# Altium schematic sheet sch3.SchDoc
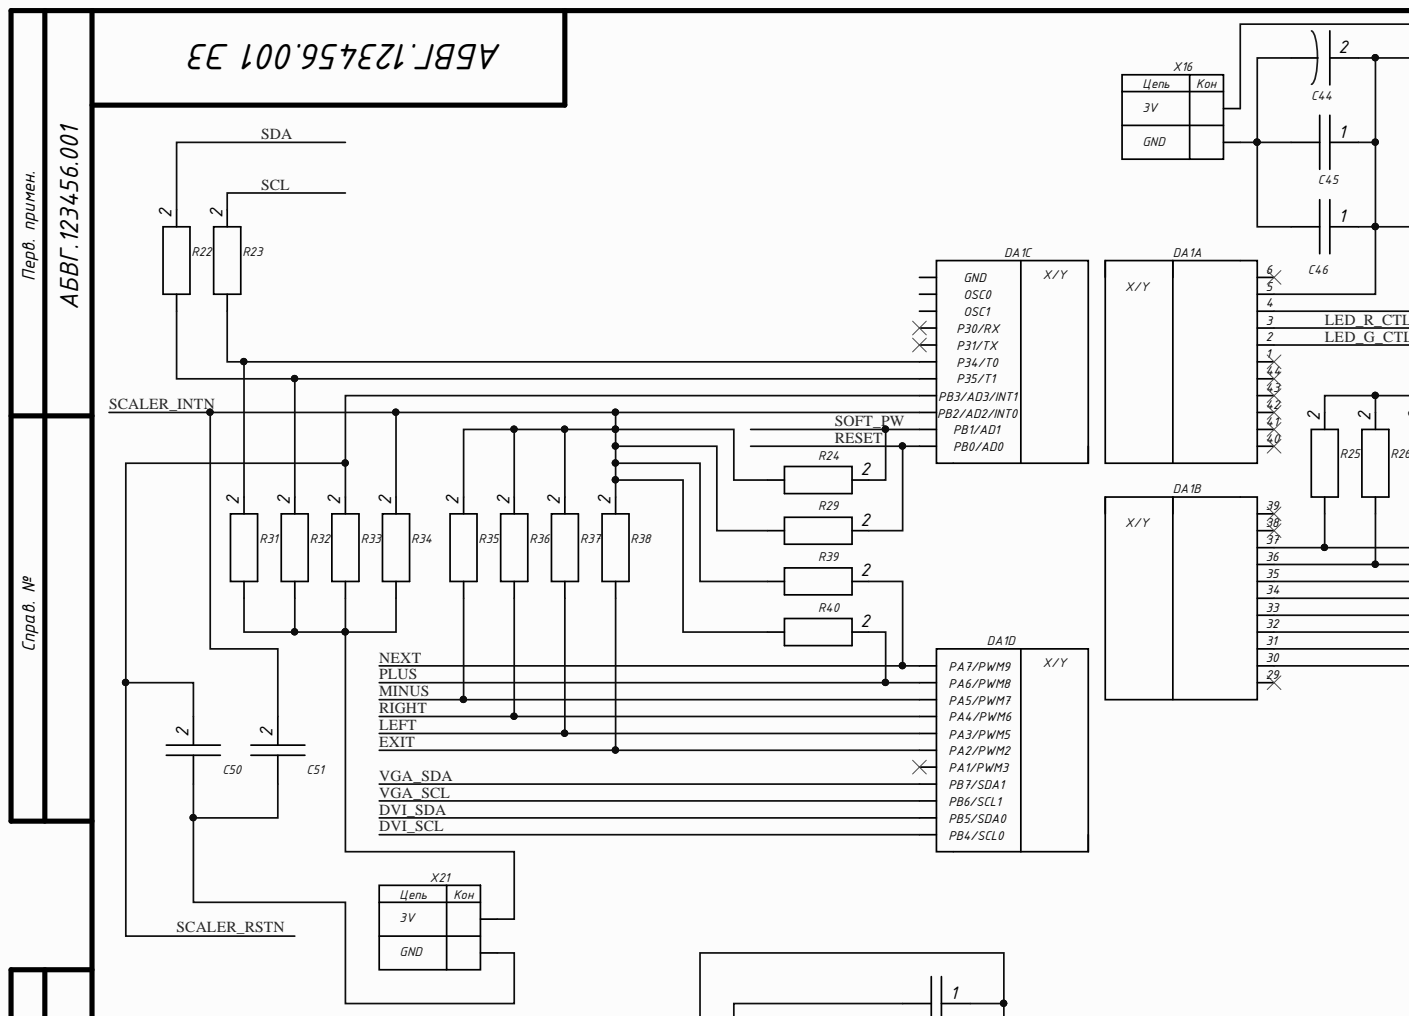
[diagram p0: sch3.SchDoc, top left]
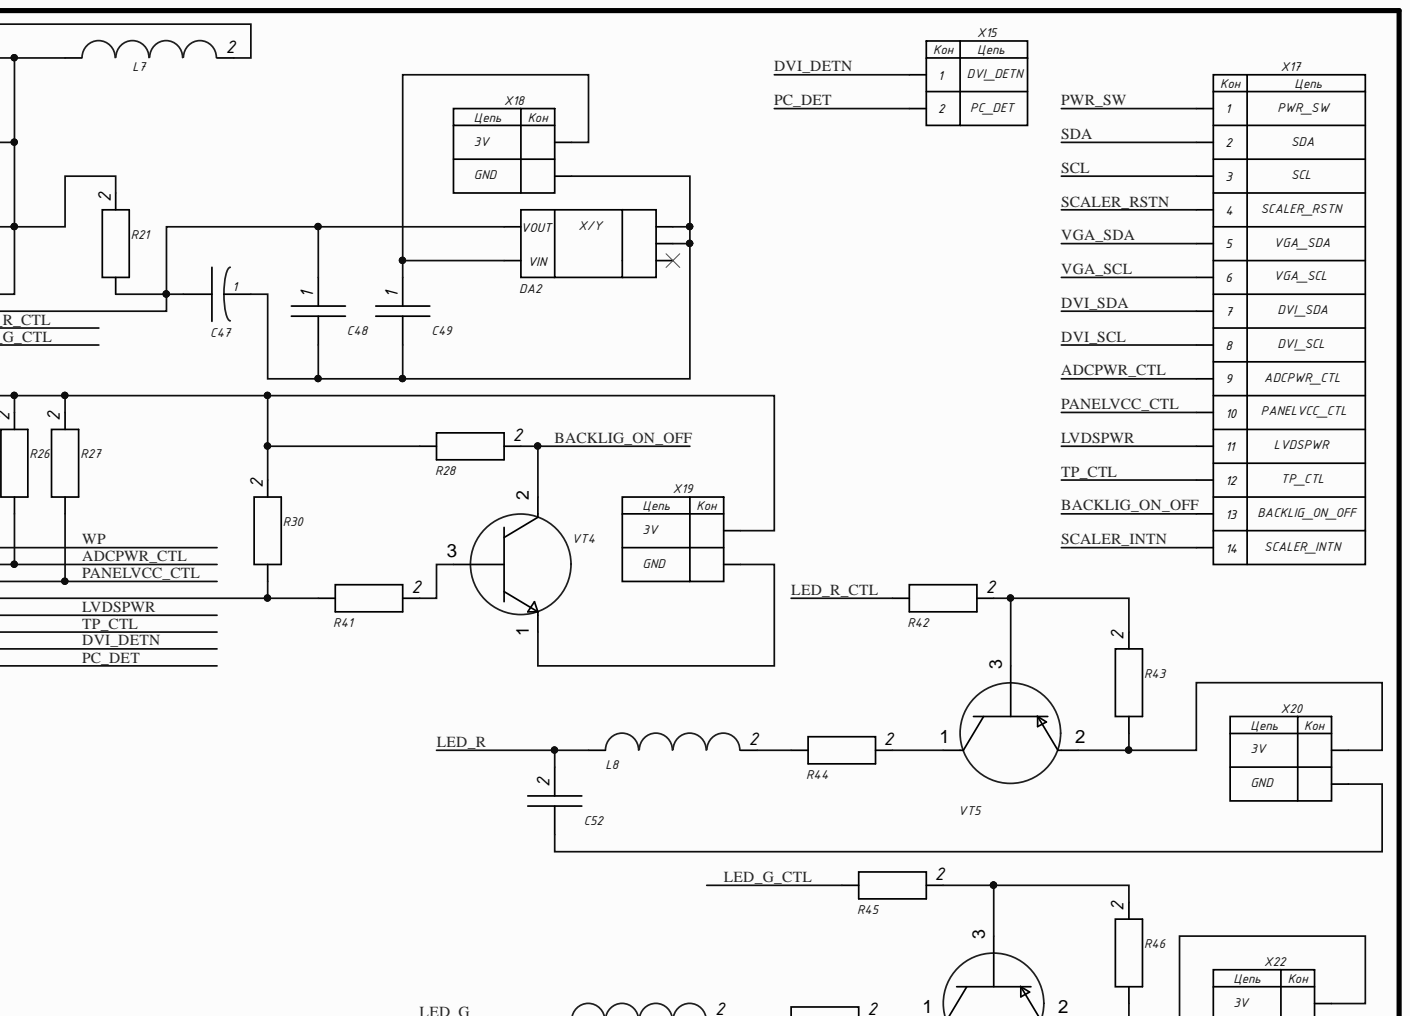
[diagram p1: sch3.SchDoc, top right]
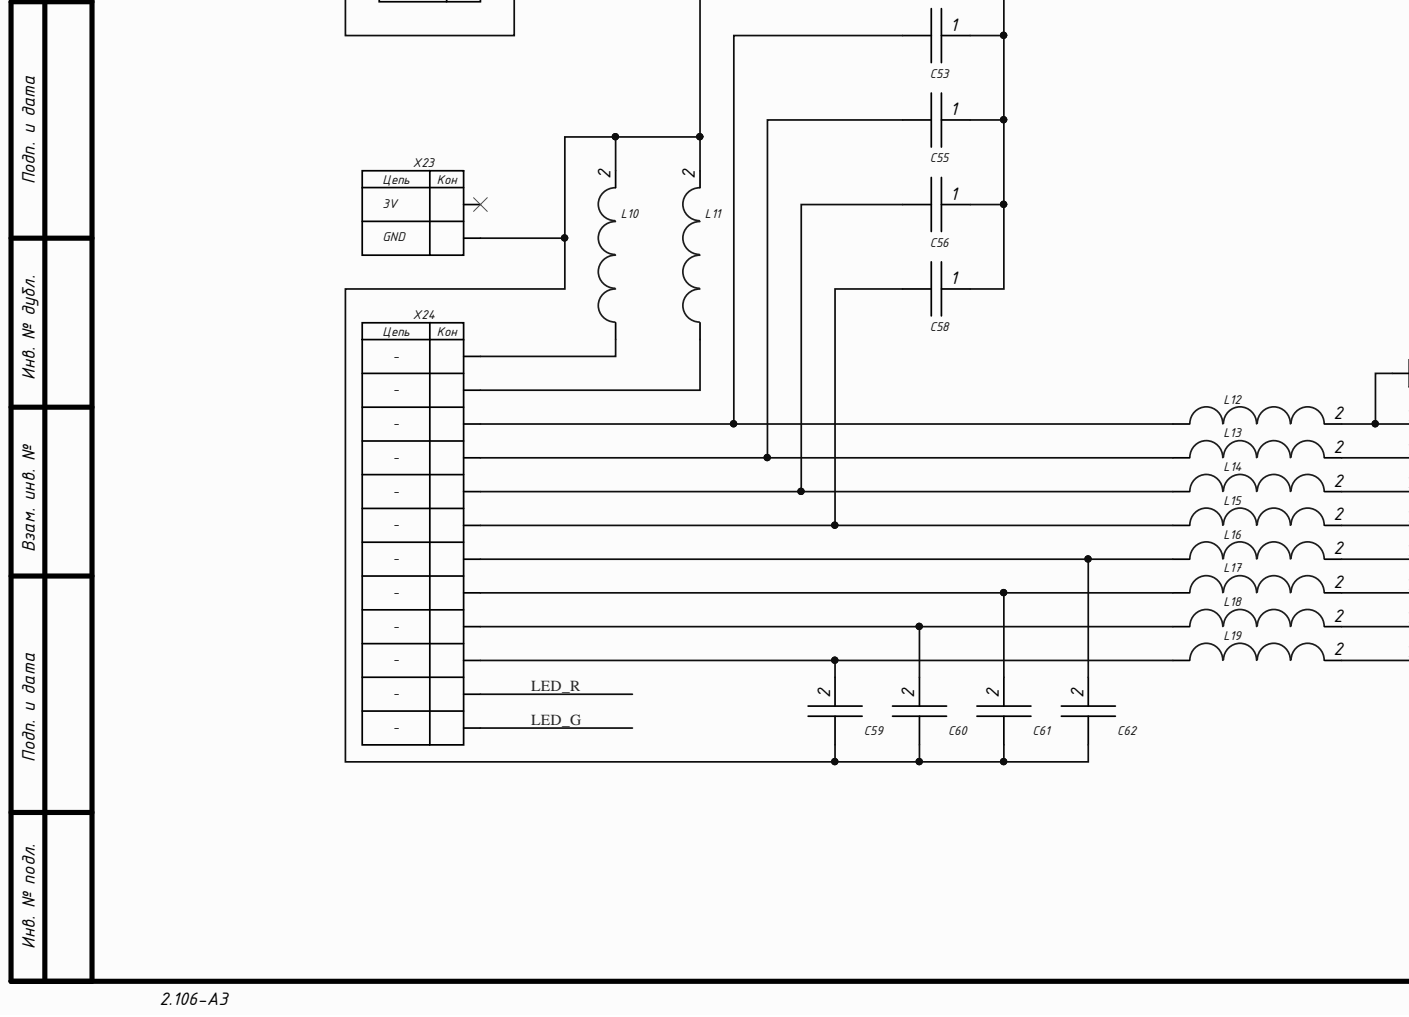
[diagram p2: sch3.SchDoc, bottom left]
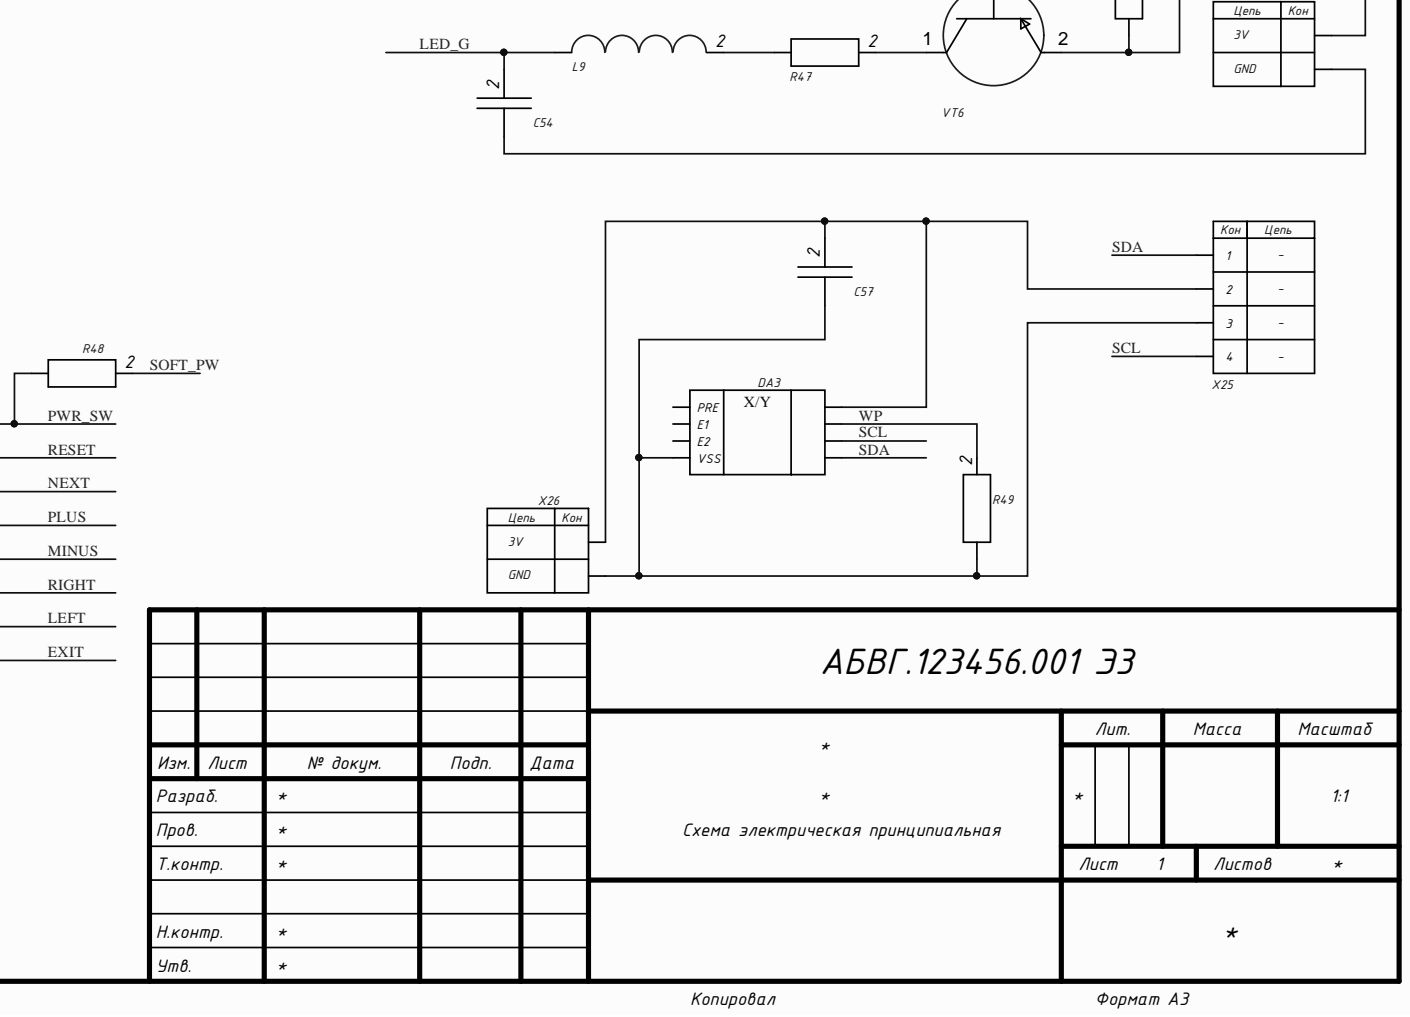
[diagram p3: sch3.SchDoc, bottom right]

## Parts
C44  (no value)  [Capacitor 0805]
C45  (no value)  [Capacitor 0805]
C46  (no value)  [Capacitor 0805]
C47  (no value)  [Capacitor 0805]
C48  (no value)  [Capacitor 0805]
C49  (no value)  [Capacitor 0805]
C50  (no value)  [Capacitor 0805]
C51  (no value)  [Capacitor 0805]
C52  (no value)  [Capacitor 0805]
C53  (no value)  [Capacitor 0805]
C54  (no value)  [Capacitor 0805]
C55  (no value)  [Capacitor 0805]
C56  (no value)  [Capacitor 0805]
C57  (no value)  [Capacitor 0805]
C58  (no value)  [Capacitor 0805]
C59  (no value)  [Capacitor 0805]
C60  (no value)  [Capacitor 0805]
C61  (no value)  [Capacitor 0805]
C62  (no value)  [Capacitor 0805]
DA1  (no value)  [NT68]  (parts A,B,C,D)
DA2  (no value)  [IC103]
DA3  (no value)  [IC102]
L7  (no value)  [Inductor 2520]
L8  (no value)  [Inductor 2520]
L9  (no value)  [Inductor 2520]
L10  (no value)  [Inductor 2520]
L11  (no value)  [Inductor 2520]
L12  (no value)  [Inductor 2520]
L13  (no value)  [Inductor 2520]
L14  (no value)  [Inductor 2520]
L15  (no value)  [Inductor 2520]
L16  (no value)  [Inductor 2520]
L17  (no value)  [Inductor 2520]
L18  (no value)  [Inductor 2520]
L19  (no value)  [Inductor 2520]
R21  (no value)  [Resistor 1206]
R22  (no value)  [Resistor 1206]
R23  (no value)  [Resistor 1206]
R24  (no value)  [Resistor 1206]
R25  (no value)  [Resistor 1206]
R26  (no value)  [Resistor 1206]
R27  (no value)  [Resistor 1206]
R28  (no value)  [Resistor 1206]
R29  (no value)  [Resistor 1206]
R30  (no value)  [Resistor 1206]
R31  (no value)  [Resistor 1206]
R32  (no value)  [Resistor 1206]
R33  (no value)  [Resistor 1206]
R34  (no value)  [Resistor 1206]
R35  (no value)  [Resistor 1206]
R36  (no value)  [Resistor 1206]
R37  (no value)  [Resistor 1206]
R38  (no value)  [Resistor 1206]
R39  (no value)  [Resistor 1206]
R40  (no value)  [Resistor 1206]
R41  (no value)  [Resistor 1206]
R42  (no value)  [Resistor 1206]
R43  (no value)  [Resistor 1206]
R44  (no value)  [Resistor 1206]
R45  (no value)  [Resistor 1206]
R46  (no value)  [Resistor 1206]
R47  (no value)  [Resistor 1206]
R48  (no value)  [Resistor 1206]
R49  (no value)  [Resistor 1206]
VT4  (no value)  [Transistor 1]
VT5  (no value)  [Transistor 1]
VT6  (no value)  [Transistor 1]
X15  (no value)  [Inputs]
X16  (no value)  [VCC-GND]
X17  (no value)  [Outputs]
X18  (no value)  [VCC-GND]
X19  (no value)  [VCC-GND]
X20  (no value)  [VCC-GND]
X21  (no value)  [VCC-GND]
X22  (no value)  [VCC-GND]
X23  (no value)  [VCC-GND]
X24  (no value)  [J101]
X25  (no value)  [J102]
X26  (no value)  [VCC-GND]

## Nets
ADCPWR_CTL: DA1.36 R26.1 X17.9
BACKLIG_ON_OFF: R28.2 VT4.2 X17.13
DVI_DETN: DA1.31 X15.1
DVI_SCL: DA1.28(PB4/SCL0) X17.8
DVI_SDA: DA1.27(PB5/SDA0) X17.7
EXIT: DA1.23(PA2/PWM2) L19.2 R38.1
LED_G: C54.2 L9.1 X24.12
LED_G_CTL: DA1.2 R45.1
LED_R: C52.2 L8.1 X24.11
LED_R_CTL: DA1.3 R42.1
LEFT: DA1.22(PA3/PWM5) L18.2 R37.1
LVDSPWR: DA1.33 X17.11
MINUS: DA1.20(PA5/PWM7) L16.2 R35.1
NEXT: DA1.18(PA7/PWM9) L14.2 R39.2
PANELVCC_CTL: DA1.35 R27.1 X17.10
PC_DET: DA1.30 X15.2
PLUS: DA1.19(PA6/PWM8) L15.2 R40.2
PWR_SW: L12.2 R48.1 X17.1
RESET: DA1.17(PB0/AD0) L13.2 R29.2
RIGHT: DA1.21(PA4/PWM6) L17.2 R36.1
SCALER_INTN: C51.2 DA1.15(PB2/AD2/INT0) R24.1 R29.1 R34.2 R35.2 R36.2 R37.2 R38.2 R39.1 R40.1 X17.14
SCALER_RSTN: C50.2 DA1.14(PB3/AD3/INT1) R33.2 X17.4
SCL: DA3.7 R23.2 X17.3 X25.4
SDA: DA3.8 R22.2 X17.2 X25.1
SOFT_PW: DA1.16(PB1/AD1) R24.2 R48.2
TP_CTL: DA1.32 X17.12
VGA_SCL: DA1.26(PB6/SCL1) X17.6
VGA_SDA: DA1.25(PB7/SDA1) X17.5
WP: DA1.37 DA3.6 R25.1 R49.2
NetC44_1: C44.1 C45.2 C46.2 X16.2
NetC44_2: C44.2 C45.1 C46.1 DA1.5 L7.1 R21.2
NetC47_1: C47.1 C48.2 C49.2 DA2.1 DA2.2 X18.2
NetC47_2: C47.2 C48.1 DA1.4 DA2.4(VOUT) R21.1
NetC49_1: C49.1 DA2.5(VIN) X18.1
NetC50_1: C50.1 C51.1 X21.2
NetC52_1: C52.1 X20.2
NetC53_1: C53.1 C55.1 C56.1 C58.1 C59.1 C60.1 C61.1 C62.1 L10.2 L11.2 X23.2
NetC53_2: C53.2 L12.1 X24.3
NetC54_1: C54.1 X22.2
NetC55_2: C55.2 L13.1 X24.4
NetC56_2: C56.2 L14.1 X24.5
NetC57_1: C57.1 DA3.4(VSS) R49.1 X25.3 X26.2
NetC57_2: C57.2 DA3.5 X25.2 X26.1
NetC58_2: C58.2 L15.1 X24.6
NetC59_2: C59.2 L19.1 X24.10
NetC60_2: C60.2 L18.1 X24.9
NetC61_2: C61.2 L17.1 X24.8
NetC62_2: C62.2 L16.1 X24.7
NetDA1_12: DA1.12(P34/T0) R23.1 R31.2
NetDA1_13: DA1.13(P35/T1) R22.1 R32.2
NetDA1_34: DA1.34 R30.1 R41.1
NetL7_2: L7.2 X16.1
NetL8_2: L8.2 R44.1
NetL9_2: L9.2 R47.1
NetL10_1: L10.1 X24.1
NetL11_1: L11.1 X24.2
NetR25_2: R25.2 R26.2 R27.2 R28.1 R30.2 X19.1
NetR31_1: R31.1 R32.1 R33.1 R34.1 X21.1
NetR41_2: R41.2 VT4.3
NetR42_2: R42.2 R43.2 VT5.3
NetR43_1: R43.1 VT5.2 X20.1
NetR44_2: R44.2 VT5.1
NetR45_2: R45.2 R46.2 VT6.3
NetR46_1: R46.1 VT6.2 X22.1
NetR47_2: R47.2 VT6.1
NetVT4_1: VT4.1 X19.2

Not connected: DA1 1 6 7 8 9 10(P30/RX) 11(P31/TX) 24(PA1/PWM3) 29 38 39 40 41 42 43 44; DA2 3; DA3 1(PRE) 2(E1) 3(E2); X23 1

## Library data of the parts
C44 C47 = Capacitor Duga: Component Kind: Standard; Library Name: k7shared.IntLib
C45 C46 C48-C62 = Capacitor: Component Kind: Standard; Library Name: k7shared.IntLib
DA1 = NT68: Component Kind: Standard; Library Name: k7sch3.IntLib
DA2 = IC103: Component Kind: Standard; Library Name: k7sch3.IntLib
DA3 = IC102: Component Kind: Standard; Library Name: k7sch3.IntLib
L7-L19 = Inductor: Component Kind: Standard; Library Name: k7shared.IntLib
R21-R49 = Resistor: Component Kind: Standard; Library Name: k7shared.IntLib
VT4 = Transistor 1: Component Kind: Standard; Library Name: k7shared.IntLib
VT5 VT6 = Transistor 2: Component Kind: Standard; Library Name: k7shared.IntLib
X15 = Inputs: Component Kind: Standard; Library Name: k7sch3.IntLib
X16 X18-X23 X26 = VCC-GND R: Component Kind: Standard; Library Name: k7shared.IntLib
X17 = Outputs: Component Kind: Standard; Library Name: k7sch3.IntLib
X24 = J101: Component Kind: Standard; Library Name: k7sch3.IntLib
X25 = J102: Component Kind: Standard; Library Name: k7sch3.IntLib
